# Altium schematic sheet 01-Antennas.SchDoc(01-Antennas)
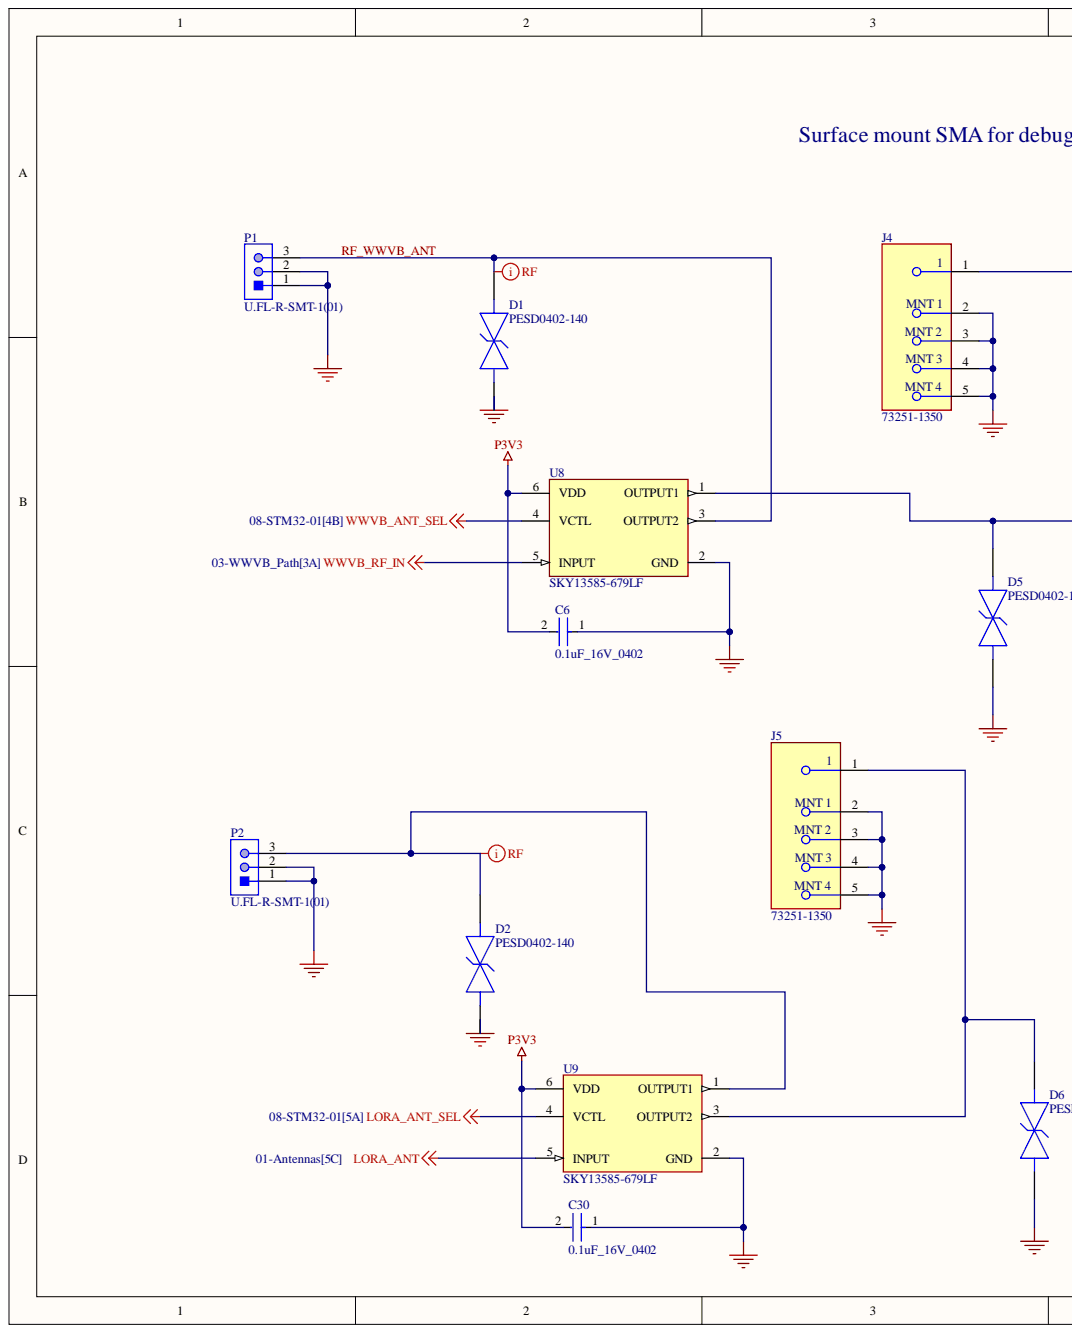
[diagram p0: 01-Antennas.SchDoc, left]
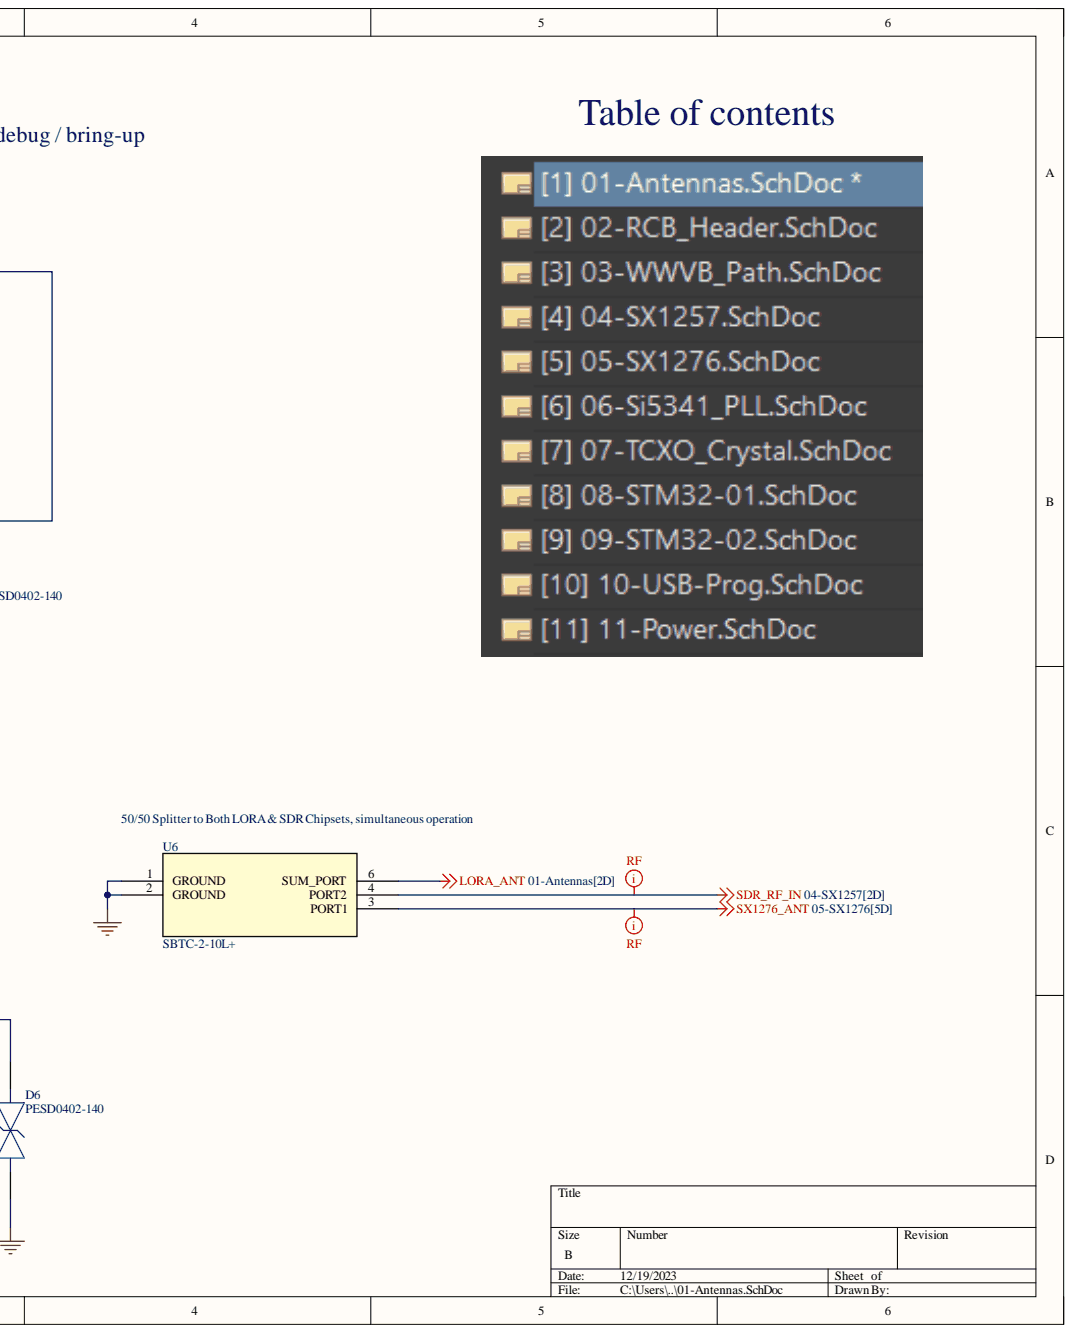
[diagram p1: 01-Antennas.SchDoc, right]

## Parts
C6  0.1uF_16V_0402  [FP-C0402-BB-MFG]
C30  0.1uF_16V_0402  [FP-C0402-BB-MFG]
D1  PESD0402-140  [FP-PESD0402-MFG]
D2  PESD0402-140  [FP-PESD0402-MFG]
D5  PESD0402-140  [FP-PESD0402-MFG]
D6  PESD0402-140  [FP-PESD0402-MFG]
J4  73251-1350  [FP-73251-1350-MFG]
J5  73251-1350  [FP-73251-1350-MFG]
P1  U.FL-R-SMT-1(01)  [HRS-U.FL-R-SMT-3_V]
P2  U.FL-R-SMT-1(01)  [HRS-U.FL-R-SMT-3_V]
U6  SBTC-2-10L+  [AT1029_MNC]
U8  SKY13585-679LF  [FP-SKY13585-679LF-MFG]
U9  SKY13585-679LF  [FP-SKY13585-679LF-MFG]

## Nets
GND: C6.1 C30.1 D1.1 D2.1 D5.1 D6.1 J4.2 J4.3 J4.4 J4.5 J5.2 J5.3 J5.4 J5.5 P1.1 P1.2 P2.1 P2.2 U6.1(GROUND) U6.2(GROUND) U8.2(GND) U9.2(GND)
LORA_ANT: U6.6(SUM_PORT) U9.5(INPUT)
LORA_ANT_SEL: U9.4(VCTL)
P3V3: C6.2 C30.2 U8.6(VDD) U9.6(VDD)
SDR_RF_IN: U6.4(PORT2)
SX1276_ANT: U6.3(PORT1)
WWVB_ANT_SEL: U8.4(VCTL)
WWVB_RF_IN: U8.5(INPUT)
RF_WWVB_ANT: D1.2 P1.3 U8.3(OUTPUT2)
NetD2_2: D2.2 P2.3 U9.1(OUTPUT1)
NetD5_2: D5.2 J4.1 U8.1(OUTPUT1)
NetD6_2: D6.2 J5.1 U9.3(OUTPUT2)

## Library data of the parts
C6 C30 = CMP-1034-02068-3: CAP CER 0.1UF 16V 5% X7R 0402; Manufacturer: Kemet; Manufacturer Part Number: C0402C104J4RACTU; Capacitance: 0.10 μF; Material: Ceramic; Temperature Coefficient: 15%; Tolerance: 0.05; Value: 0.10 μF; Automotive: No; Category: Cap; Component Kind: Standard; ComponentLink2Description: Datasheet; ComponentLink2URL: https://content.kemet.com/datasheets/KEM_C1002_X7R_SMD.pdf; Device Class L1: Passive Components; Device Class L2: Capacitors; Device Class L3: Ceramic Capacitors; Digikey Description: CAP CER 0.1UF 16V 5% X7R 0402; Height: 0.55mm; Ipc Land Pattern Name: CAPC10050X50; Lead Free: Yes; Library Name: Altium Content Vault; Mouser Description: CAP CER 0.1UF 16V X7R 0402; Octopart: https://octopart.com/c0402c104j4ractu-kemet-12573893; Package: C0402-BB; RoHS: TRUE; Temperature: -55°C to +125°C; Temperature Characteristic: X7R; Temperature Range High: +125°C; Temperature Range Low: -55°C; Voltage Rating: 16 V
D1 D2 D5 D6 = CMP-08607-000011-1: TVS DIODE 14V 40V 0402; Manufacturer: Littelfuse Inc.; Automotive Grade: Grade 1; Capacitance: 0.25pF; Direction: Bidirectional; Number Of Channels: 1; Value: 0.25pF; Automotive: Yes; Breakdown Voltage: 14V; Category: Diode; Clamping Voltage: 40V; Component Kind: Standard; ComponentLink2Description: Datasheet; ComponentLink2URL: https://www.littelfuse.com/~/media/electronics/datasheets/polymer_esd_suppressors/littelfuse_polymer_esd_suppressor_pesd_catalog_datasheet.pdf.pdf; Device Class L1: Circuit Protection; Device Class L2: TVS Diodes; Device Class L3: unset; Digikey Description: TVS DIODE 14V 40V 0402; Height: 0.43mm; Ipc Land Pattern Name: DIOC10050X33; Lead Free: Yes; Library Name: Altium Content Vault; Manufacturer Part Number: PESD0402-140; Octopart: https://octopart.com/pesd0402-140-littelfuse-74215061; Package: PESD0402; Reverse Standoff Voltage: 14V; RoHS: TRUE; Temperature: -55°C to +125°C; Temperature Range High: +125°C; Temperature Range Low: -55°C
J4 J5 = CMP-04764-000006-1: CONN SMA JACK STR 50 OHM SMD; Manufacturer: Molex; Conn Orientation: Straight; Frequency: 18GHz; Impedance: 50Ω; Is Female: yes; Number Of Contacts: 1; Shielding: true; Standoff Height: 0.41mm; Voltage Rating: 500V; Automotive: No; Category: Conn; Component Kind: Standard; ComponentLink2Description: Datasheet; ComponentLink2URL: https://www.molex.com/pdm_docs/sd/732511350_sd.pdf; Contact Material: Gold; Device Class L1: Connectors; Device Class L2: RF / Coaxial Connectors; Device Class L3: unset; Digikey Description: CONN SMA JACK STR 50 OHM SMD; Footprint Url: https://forum.upverter.com/uploads/default/original/3X/d/a/daeafa2ce4908d4fc4e49f0b17d7d2c986fce444.pdf; Height: 9.655mm; Lead Free: Yes; Library Name: Altium Content Vault; Manufacturer Part Number: 73251-1350; Mouser Description: RF Connectors / Coaxial Connectors SMA ST PCB-JCK STD SMT; Octopart: https://octopart.com/73251-1350-molex-5414390; Package: 73251-1350; RoHS: TRUE; Temperature: -65°C to +165°C; Temperature Range High: +165°C; Temperature Range Low: -65°C
P1 P2 = CMP-2000-05153-1: Ultra Small Coaxial Connector, 6 GHz, -40 to 90 degC, 3-Pin SMD, RoHS, Bulk; Manufacturer: Hirose Electric; Body Finish: Silver; Body Material: PhosphorBronze; Center Contact Plating: Gold; Connector Style: U.FL; Connector Type: Jack,MalePin; Contact Termination: Solder; Dielectric Material: LiquidCrystalPolymer(LCP); Dynamic Catalog: U.FLSeries; Featured Product: HiroseU.FLSeries; Frequency - Max: 6GHz; Impedance: 50Ohm; Minimum Quantity: UltraMiniatureCoaxial; Other Names: H122041  U.FL-R-SMT-1(01)-ND; Category: Connectors,Interconnects; Center Contact Material: PhosphorBronze; Component Kind: Standard; Datasheet URL: https://datasheet.ciiva.com/26945/getdatasheetpartid-475239-26945414.pdf; Datasheet Version: Feb-09; Family: CoaxialConnectors(RF); Fastening Type: Snap-On; Housing Color: Silver; Library Name: Altium Content Vault; Manufacturer URL: https://www.hirose.com; Mounting Type: SurfaceMount; Online Catalog: U.FLSeries; Operating Temperature: -40degC~90degC; Package Description: 3-Pin Surface Mount Device, Body 2.6 x 2.6 mm; Package Reference: U.FL-R-SMT; Packaging: Bulk; Quantity Available: Bulk; Series: U.FL; Shield Termination: Solder; Standard Package: 100; Unit PriceUSD: U.FL
U6 = SBTC-2-10L+: No Description Available; Mfr_Name: Mini Circuits; Component Kind: Standard; Library Name: SBTC-2-10L&plus_.SchLib; Manufacturer_Part_Number: SBTC-2-10L+; RefDes: RefDes; Type: DEV; Copyright: Copyright (C) 2023 Ultra Librarian. All rights reserved.
U8 U9 = CMP-85923-000009-1: IC RF SWITCH SPDT 6GHZ 6MLPD; IIP3: 56dBm; Impedance: 50R; Insertion Loss: 0.5dB; Test Frequency: 2.5GHz; Component Kind: Standard; Library Name: Altium Content Vault; Max Operating Temperature: 90°C; Min Operating Temperature: -40°C; Packaging: Cut Tape; RoHS Compliant: Yes; Series: -; Throw Configuration: SPDT
